# Altium schematic sheet Connectors.Schdoc(Connectors)
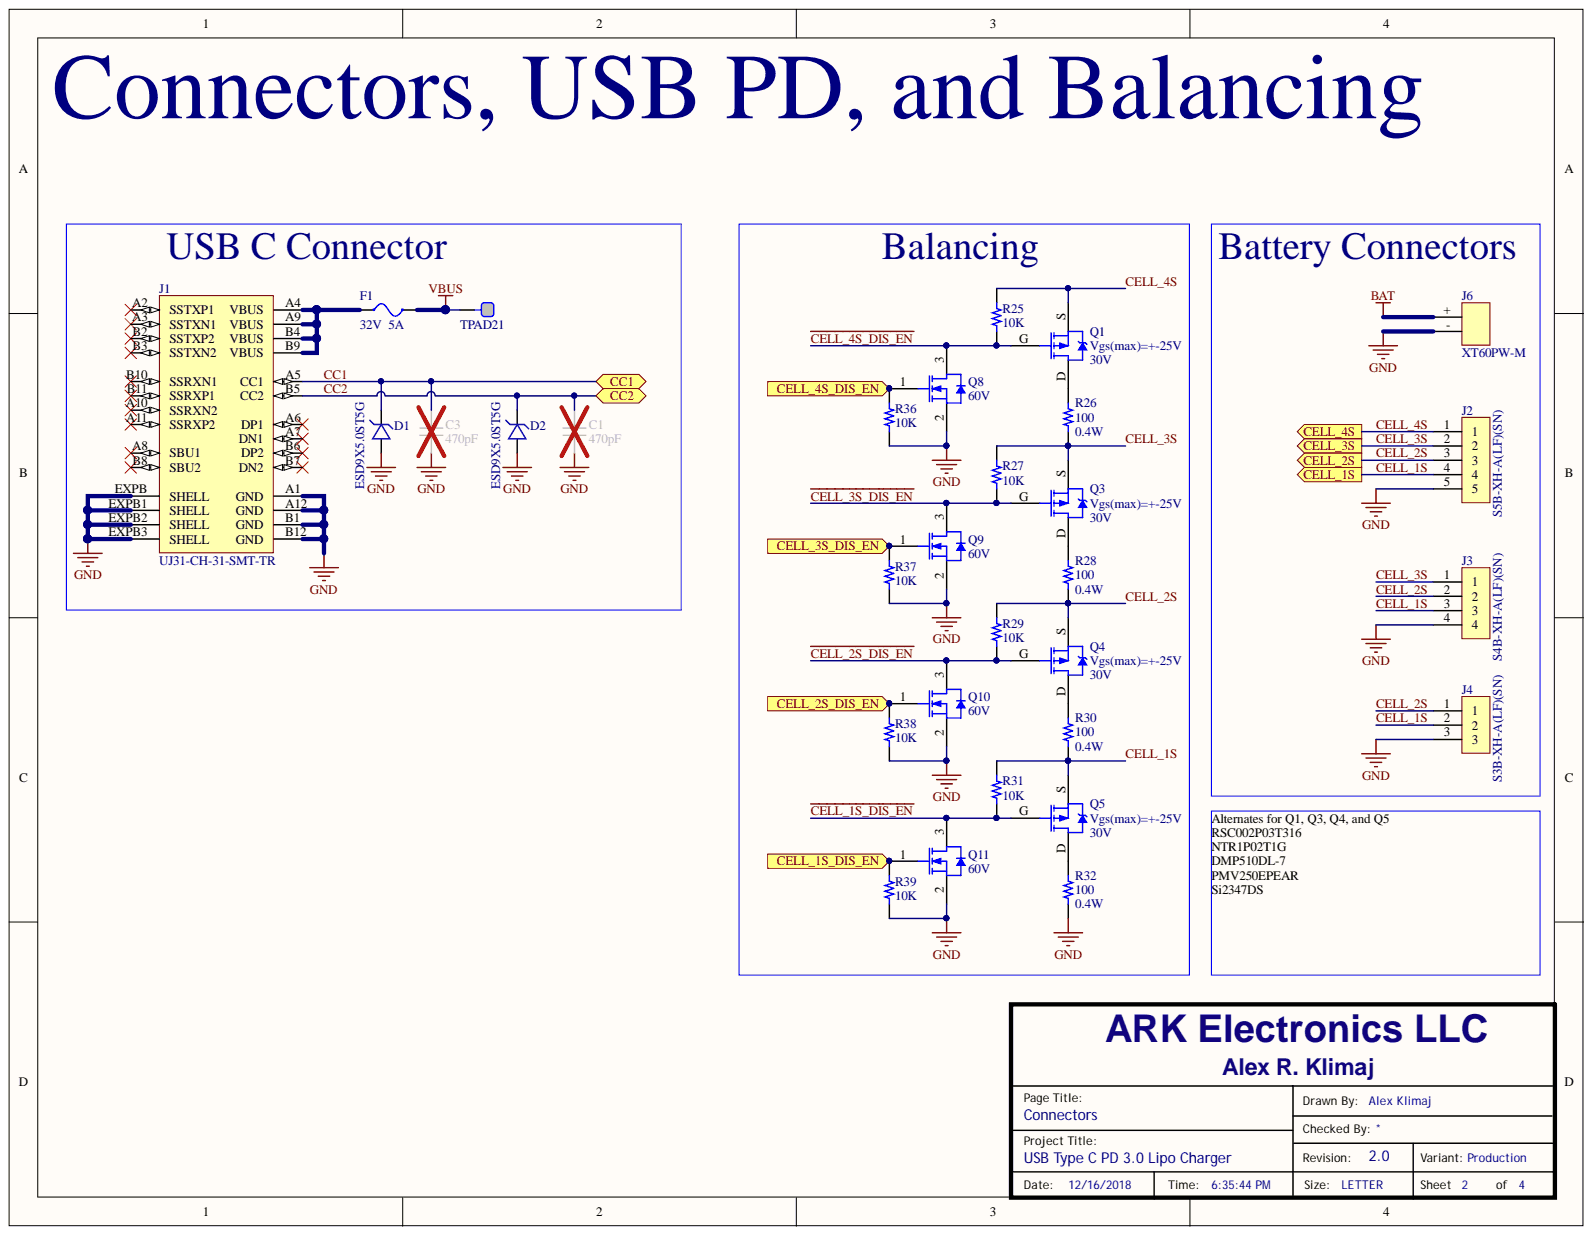
[diagram p0: Connectors.Schdoc]

## Parts
C1  470pF  [CAPC0805(2012)155_N]
C3  470pF  [CAPC0805(2012)155_N]
D1  ESD9X5.0ST5G  [SOD-923]
D2  ESD9X5.0ST5G  [SOD-923]
F1  Voltage_Rating: 32V  Current_Rating: 5A  [Fuse 0603]  (hidden comment: MFU0603FF05000P100)
J1  UJ31-CH-31-SMT-TR  [CUI_UJ31-CH-31-SMT-TR_UJ31-CH-31-SMT-TR(Primary)]
J2  value S5B-XH-A(LF)(SN)  [S5B-XH-A]  (hidden comment: S5B-XH-A 5 Pin Header)
J3  S4B-XH-A(LF)(SN)  [S4B-XH-A]
J4  S3B-XH-A(LF)(SN)  [S3B-XH-A]
J6  XT60PW-M  [XT60PW-M]
Q1  Vgs(max)=+-25V  Voltage_Rating: 30V  [Diode SOT23 PMOS]
Q3  Vgs(max)=+-25V  Voltage_Rating: 30V  [Diode SOT23 PMOS]
Q4  Vgs(max)=+-25V  Voltage_Rating: 30V  [Diode SOT23 PMOS]
Q5  Vgs(max)=+-25V  Voltage_Rating: 30V  [Diode SOT23 PMOS]
Q8  Voltage_Rating: 60V  [TO-236AB (SOT23)]  (hidden comment: NX7002AK,215)
Q9  Voltage_Rating: 60V  [TO-236AB (SOT23)]  (hidden comment: NX7002AK,215)
Q10  Voltage_Rating: 60V  [TO-236AB (SOT23)]  (hidden comment: NX7002AK,215)
Q11  Voltage_Rating: 60V  [TO-236AB (SOT23)]  (hidden comment: NX7002AK,215)
R25  10K  [RESC0805(2012)_L]
R26  100  Power_Rating: 0.4W  [RESC0805(2012)_L]
R27  10K  [RESC0805(2012)_L]
R28  100  Power_Rating: 0.4W  [RESC0805(2012)_L]
R29  10K  [RESC0805(2012)_L]
R30  100  Power_Rating: 0.4W  [RESC0805(2012)_L]
R31  10K  [RESC0805(2012)_L]
R32  100  Power_Rating: 0.4W  [RESC0805(2012)_L]
R36  10K  [RESC0805(2012)_L]
R37  10K  [RESC0805(2012)_L]
R38  10K  [RESC0805(2012)_L]
R39  10K  [RESC0805(2012)_L]
TPAD21  TEST_PAD  [TEST_POINT]  (value not drawn)  (no BOM)

## Ports
CC1, CC2, CELL_1S, CELL_1S_DIS_EN, CELL_2S, CELL_2S_DIS_EN, CELL_3S, CELL_3S_DIS_EN, CELL_4S, CELL_4S_DIS_EN

## Nets
BAT: J6.+
GND: C1.1 C3.1 D1.2 D2.2 J1.A1(GND) J1.A12(GND) J1.B1(GND) J1.B12(GND) J1.EXPB(SHELL) J1.EXPB1(SHELL) J1.EXPB2(SHELL) J1.EXPB3(SHELL) J2.5 J3.4 J4.3 J6.- Q8.2 Q9.2 Q10.2 Q11.2 R32.2 R36.2 R37.2 R38.2 R39.2
VBUS: F1.1 TPAD21.1
~{CELL_1S_DIS_EN}: Q5.G Q11.3 R31.2
~{CELL_2S_DIS_EN}: Q4.G Q10.3 R29.2
~{CELL_3S_DIS_EN}: Q3.G Q9.3 R27.2
~{CELL_4S_DIS_EN}: Q1.G Q8.3 R25.2
CC1: C3.2 D1.1 J1.A5(CC1) ; port CC1
CC2: C1.2 D2.1 J1.B5(CC2) ; port CC2
CELL_1S: J2.4 J3.3 J4.2 Q5.S R30.2 R31.1 ; port CELL_1S
CELL_1S_DIS_EN: Q11.1 R39.1 ; port CELL_1S_DIS_EN
CELL_2S: J2.3 J3.2 J4.1 Q4.S R28.2 R29.1 ; port CELL_2S
CELL_2S_DIS_EN: Q10.1 R38.1 ; port CELL_2S_DIS_EN
CELL_3S: J2.2 J3.1 Q3.S R26.2 R27.1 ; port CELL_3S
CELL_3S_DIS_EN: Q9.1 R37.1 ; port CELL_3S_DIS_EN
CELL_4S: J2.1 Q1.S R25.1 ; port CELL_4S
CELL_4S_DIS_EN: Q8.1 R36.1 ; port CELL_4S_DIS_EN
NetF1_2: F1.2 J1.A4(VBUS) J1.A9(VBUS) J1.B4(VBUS) J1.B9(VBUS)
NetQ1_D: Q1.D R26.1
NetQ3_D: Q3.D R28.1
NetQ4_D: Q4.D R30.1
NetQ5_D: Q5.D R32.1

Not connected: J1 A2(SSTXP1) A3(SSTXN1) A6(DP1) A7(DN1) A8(SBU1) A10(SSRXN2) A11(SSRXP2) B2(SSTXP2) B3(SSTXN2) B6(DP2) B7(DN2) B8(SBU2) B10(SSRXN1) B11(SSRXP1)

## Library data of the parts
C1 C3 = 30241: SMT Capacitor; Mfr Part Number: 885012207058; Mfr Part Description: CAP CER 470pF ±10% 25V X7R 0805 (2012 Metric); Manufacturer: Wurth Electronics Inc.; Voltage_Rating: 25V; Updated By: aklimaj; Tolerance: ±10%; Dielectric: X7R; Database Table Name: Parts; Value: 470pF; Updated_Date: 2018/11/03 20:44:34; Temperature Range: -55C to +125C; Supplier Part Number: 732-12278-1-ND; Supplier: Digi-Key; RoHS: Yes; Part_Type: Capacitor; Package_Case: 0805 (2012 Metric); LifeCycle: New; Library Name: Parts.DbLib; ID: 30241; Created_Date: 2018/11/03 20:44:15; ComponentLink2URL: https://www.digikey.com/product-detail/en/wurth-electronics-inc/885012207058/732-12278-1-ND/9346298; ComponentLink2Description: Supplier Part Details; ComponentLink1URL: https://katalog.we-online.de/pbs/datasheet/885012207058.pdf; ComponentLink1Description: Datasheet; Component Kind: Standard; Created By: aklimaj
D1 D2 = 30239: TVS Diode; Part_Type: Protection; Mfr Part Description: TVS DIODE 5V 12.3V SOD923; Manufacturer: ON Semiconductor; Updated By: aklimaj; Database Table Name: Parts; Current_Rating: 8.7A (8/20µs); Value: ESD9X5.0ST5G; Updated_Date: 2018/11/03 11:39:36; Temperature Range: -55C to +150C (TJ); Supplier Part Number: ESD9X5.0ST5GOSCT-ND; Supplier: Digi-Key; RoHS: Yes; Package_Case: SOD-923; Mfr Part Number: ESD9X5.0ST5G; LifeCycle: New; Library Name: Parts.DbLib; ID: 30239; Created_Date: 2018/11/03 11:31:29; ComponentLink2URL: https://www.digikey.com/product-detail/en/on-semiconductor/ESD9X5.0ST5G/ESD9X5.0ST5GOSCT-ND/2120624; ComponentLink2Description: Supplier Part Details; ComponentLink1URL: http://www.onsemi.com/pub/Collateral/ESD9X3.3ST5G-D.PDF; ComponentLink1Description: Datasheet; Component Kind: Standard; Created By: aklimaj
F1 = 30231: FUSE; Part_Type: Protection; Mfr Part Description: FUSE BOARD MOUNT 5A 32VDC 0603; Manufacturer: Vishay Beyschlag; Updated By: aklimaj; Database Table Name: Parts; Value: MFU0603FF05000P100; Updated_Date: 2018/10/26 21:42:25; Temperature Range: -55C to +125C; Supplier Part Number: MFU06035.00CT-ND; Supplier: Digi-Key; RoHS: Yes; Package_Case: 0603 (1608 Metric); Mfr Part Number: MFU0603FF05000P100; LifeCycle: New; Library Name: Parts.DbLib; ID: 30231; Created_Date: 2018/10/26 21:34:10; ComponentLink2URL: https://www.digikey.com/product-detail/en/vishay-beyschlag/MFU0603FF05000P100/MFU06035.00CT-ND/1878995; ComponentLink2Description: Supplier Part Details; ComponentLink1URL: http://www.vishay.com/docs/28747/mfuserie.pdf; ComponentLink1Description: Datasheet; Component Kind: Standard; Created By: aklimaj
J1 = 30219: Part_Type: Connector; Mfr Part Description: USB JACK 3.1, C TYPE, 24 PIN, HO; Manufacturer: CUI Inc.; Voltage_Rating: 20V; Updated By: aklimaj; Database Table Name: Parts; Current_Rating: 0.25A, 1.25A, 5A, 6.25A; Value: UJ31-CH-31-SMT-TR; Updated_Date: 2018/10/19 21:37:45; Temperature Range: -30C to +85C; Supplier Part Number: 102-4485-1-ND; Supplier: Digi-Key; RoHS: Yes; Mfr Part Number: UJ31-CH-31-SMT-TR; LifeCycle: New; Library Name: Parts.DbLib; ID: 30219; Created_Date: 2018/10/14 19:06:33; ComponentLink2URL: https://www.digikey.com/product-detail/en/cui-inc/UJ31-CH-31-SMT-TR/102-4485-1-ND/8024065; ComponentLink2Description: Supplier Part Details; ComponentLink1URL: https://www.cui.com/product/resource/digikeypdf/uj31-ch-smt.pdf; ComponentLink1Description: Datasheet; Component Kind: Standard; Created By: aklimaj
J2 = 30040: Teal Part Number: 20358; Part_Type: Connector; Mfr Part Description: CONN HEADER XH SIDE 5POS 2.5MM; Manufacturer: JST Sales America Inc.; Voltage_Rating: 250V; Updated By: aklimaj; Database Table Name: Parts; Current_Rating: 3A; Updated_Date: 2017/08/01 14:44:41; Temperature Range: -25C to +85C; Supplier Part Number: 455-2242-ND; Supplier: Digi-Key; RoHS: Yes; Mfr Part Number: S5B-XH-A(LF)(SN); LifeCycle: New; Library Name: Parts.DbLib; ID: 30040; Description: S5B-XH-A 5 Pin Header; Created_Date: 2017/08/01 14:44:19; ComponentLink2URL: https://www.digikey.com/products/en?keywords=S5B-XH-A; ComponentLink2Description: Supplier Part Details; ComponentLink1URL: http://www.jst-mfg.com/product/pdf/eng/eXH.pdf; ComponentLink1Description: Datasheet; Component Kind: Standard; Created By: aklimaj
J3 = 30228: Part_Type: Connector; Mfr Part Description: CONN HEADER XH SIDE 4POS 2.5MM; Manufacturer: JST Sales America Inc.; Voltage_Rating: 250V; Updated By: aklimaj; Database Table Name: Parts; Current_Rating: 3A; Value: S4B-XH-A(LF)(SN); Updated_Date: 2018/10/24 21:23:10; Temperature Range: -25C to +85C; Supplier Part Number: 455-2243-ND; Supplier: Digi-Key; RoHS: Yes; Mfr Part Number: S4B-XH-A(LF)(SN); LifeCycle: New; Library Name: Parts.DbLib; ID: 30228; Created_Date: 2018/10/24 21:15:23; ComponentLink2URL: https://www.digikey.com/product-detail/en/jst-sales-america-inc/S4B-XH-A-LF-SN/455-2243-ND/1651041; ComponentLink2Description: Supplier Part Details; ComponentLink1URL: http://www.jst-mfg.com/product/pdf/eng/eXH.pdf; ComponentLink1Description: Datasheet; Component Kind: Standard; Created By: aklimaj
J4 = 30229: Part_Type: Connector; Mfr Part Description: CONN HEADER XH SIDE 3POS 2.5MM; Manufacturer: JST Sales America Inc.; Voltage_Rating: 250V; Updated By: aklimaj; Database Table Name: Parts; Current_Rating: 3A; Value: S3B-XH-A(LF)(SN); Updated_Date: 2018/10/24 21:29:57; Temperature Range: -25C to +85C; Supplier Part Number: 455-2250-ND; Supplier: Digi-Key; RoHS: Yes; Mfr Part Number: S3B-XH-A(LF)(SN); LifeCycle: New; Library Name: Parts.DbLib; ID: 30229; Created_Date: 2018/10/24 21:25:23; ComponentLink2URL: https://www.digikey.com/product-detail/en/jst-sales-america-inc/S3B-XH-A-LF-SN/455-2250-ND/1651048; ComponentLink2Description: Supplier Part Details; ComponentLink1URL: http://www.jst-mfg.com/product/pdf/eng/eXH.pdf; ComponentLink1Description: Datasheet; Component Kind: Standard; Created By: aklimaj
J6 = 30265: Mfr Part Description: Socket; DC supply; XT60; male; PIN:2; on PCBs; THT; Colour: yellow; Manufacturer: AMASS; Voltage_Rating: 500V; Updated By: aklimaj; Database Table Name: Parts; Current_Rating: 45A; Value: XT60PW-M; Updated_Date: 2018/11/08 11:11:39; Temperature Range: -20C to 120C; Supplier Part Number: XT60PW-M; Supplier: tme.eu; RoHS: Yes; Mfr Part Number: XT60PW-M; LifeCycle: New; Library Name: Parts.DbLib; ID: 30265; Created_Date: 2018/11/08 10:32:01; ComponentLink2URL: https://www.tme.eu/en/details/xt60pw-m/dc-power-connectors/amass/; ComponentLink2Description: Supplier Part Details; ComponentLink1URL: https://www.tme.eu/en/Document/9b8d0c5eb7094295f3d3112c214d3ade/XT60PW%20SPEC.pdf; ComponentLink1Description: Datasheet; Component Kind: Standard; Created By: aklimaj
Q1 Q3-Q5 = 30266: Part_Type: Discrete; Mfr Part Description: MOSFET P-CH 30V 4.3A SOT23; Manufacturer: Diodes Incorporated; Value: DMP3056L-7; Updated By: aklimaj; Database Table Name: Parts; Current_Rating: 4.3A (Ta); Updated_Date: 2018/11/08 12:54:41; Temperature Range: -55C to +150C (TJ); Supplier Part Number: DMP3056L-7DICT-ND; Supplier: Digi-Key; RoHS: Yes; Package_Case: TO-236-3, SC-59, SOT-23-3; Mfr Part Number: DMP3056L-7; LifeCycle: New; Library Name: Parts.DbLib; ID: 30266; Created_Date: 2018/11/08 12:42:57; ComponentLink2URL: https://www.digikey.com/product-detail/en/diodes-incorporated/DMP3056L-7/DMP3056L-7DICT-ND/5126873; ComponentLink2Description: Supplier Part Details; ComponentLink1URL: https://www.diodes.com/assets/Datasheets/DMP3056L.pdf; ComponentLink1Description: Datasheet; Component Kind: Standard; Created By: aklimaj
Q8-Q11 = 30247: Part_Type: Discrete; Mfr Part Description: MOSFET N-CH 60V TO-236AB; Manufacturer: Nexperia USA Inc.; Updated By: aklimaj; Database Table Name: Parts; Current_Rating: 190mA (Ta); Value: NX7002AK,215; Updated_Date: 2018/11/03 22:14:37; Temperature Range: -55C to +150C (TJ); Supplier Part Number: 1727-1289-1-ND; Supplier: Digi-Key; RoHS: Yes; Package_Case: TO-236-3, SC-59, SOT-23-3; Mfr Part Number: NX7002AK,215; LifeCycle: New; Library Name: Parts.DbLib; ID: 30247; Created_Date: 2018/11/03 22:07:14; ComponentLink2URL: https://www.digikey.com/product-detail/en/nexperia-usa-inc/NX7002AK215/1727-1289-1-ND/4386095; ComponentLink2Description: Supplier Part Details; ComponentLink1URL: https://assets.nexperia.com/documents/data-sheet/NX7002AK.pdf; ComponentLink1Description: Datasheet; Component Kind: Standard; Created By: aklimaj
R25 R27 R29 R31 R36-R39 = 30227: SMT Resistor; Mfr Part Number: CR0805-FX-1002ELF; Mfr Part Description: RES 10K Ohm ±1% 1/8W 0805 (2012 Metric); Manufacturer: Bourns Inc.; Updated By: aklimaj; Tolerance: ±1%; Temperature Coeff: ±100ppm/degC; Power_Rating: 0.125W, 1/8W; Database Table Name: Parts; Value: 10K; Updated_Date: 2018/10/19 21:37:37; Temperature Range: -55C to +155C; Supplier Part Number: CR0805-FX-1002ELFCT-ND; Supplier: Digi-Key; RoHS: Yes; Part_Type: Resistor; Package_Case: 0805 (2012 Metric); LifeCycle: New; Library Name: Parts.DbLib; ID: 30227; Created_Date: 2018/10/16 22:16:46; ComponentLink2URL: https://www.digikey.com/product-detail/en/bourns-inc/CR0805-FX-1002ELF/CR0805-FX-1002ELFCT-ND/3592928; ComponentLink2Description: Supplier Part Details; ComponentLink1URL: https://www.bourns.com/docs/Product-Datasheets/CHPREZTR.pdf; ComponentLink1Description: Datasheet; Component Kind: Standard; Created By: aklimaj
R26 R28 R30 R32 = 30246: SMT Resistor; Mfr Part Number: ESR10EZPJ101; Mfr Part Description: RES 100 Ohm ±5% 0.4W, 2/5W 0805 (2012 Metric); Manufacturer: Rohm Semiconductor; Updated By: aklimaj; Tolerance: ±5%; Temperature Coeff: ±200ppm/degC; Database Table Name: Parts; Value: 100; Updated_Date: 2018/11/03 21:47:27; Temperature Range: -55C to +155C; Supplier Part Number: RHM100KCT-ND; Supplier: Digi-Key; RoHS: Yes; Part_Type: Resistor; Package_Case: 0805 (2012 Metric); LifeCycle: New; Library Name: Parts.DbLib; ID: 30246; Created_Date: 2018/11/03 21:46:05; ComponentLink2URL: https://www.digikey.com/product-detail/en/rohm-semiconductor/ESR10EZPJ101/RHM100KCT-ND/1762950; ComponentLink2Description: Supplier Part Details; ComponentLink1URL: https://www.rohm.com/datasheet/ESR01MZPF/esr-e; ComponentLink1Description: Datasheet; Component Kind: Standard; Created By: aklimaj
TPAD21 = 30015: Part_Type: Test Point; Updated By: aklimaj; Database Table Name: Parts; Updated_Date: 2017/07/13 09:29:11; RoHS: Yes; Mfr Part Description: Test Point; LifeCycle: New; Library Name: Parts.DbLib; ID: 30015; Description: TEST_PAD; Created_Date: 2017/07/13 09:26:35; ComponentLink2Description: Supplier Part Details; ComponentLink1Description: Datasheet; Created By: aklimaj
